# Altium schematic sheet msp430.SchDoc(msp430)
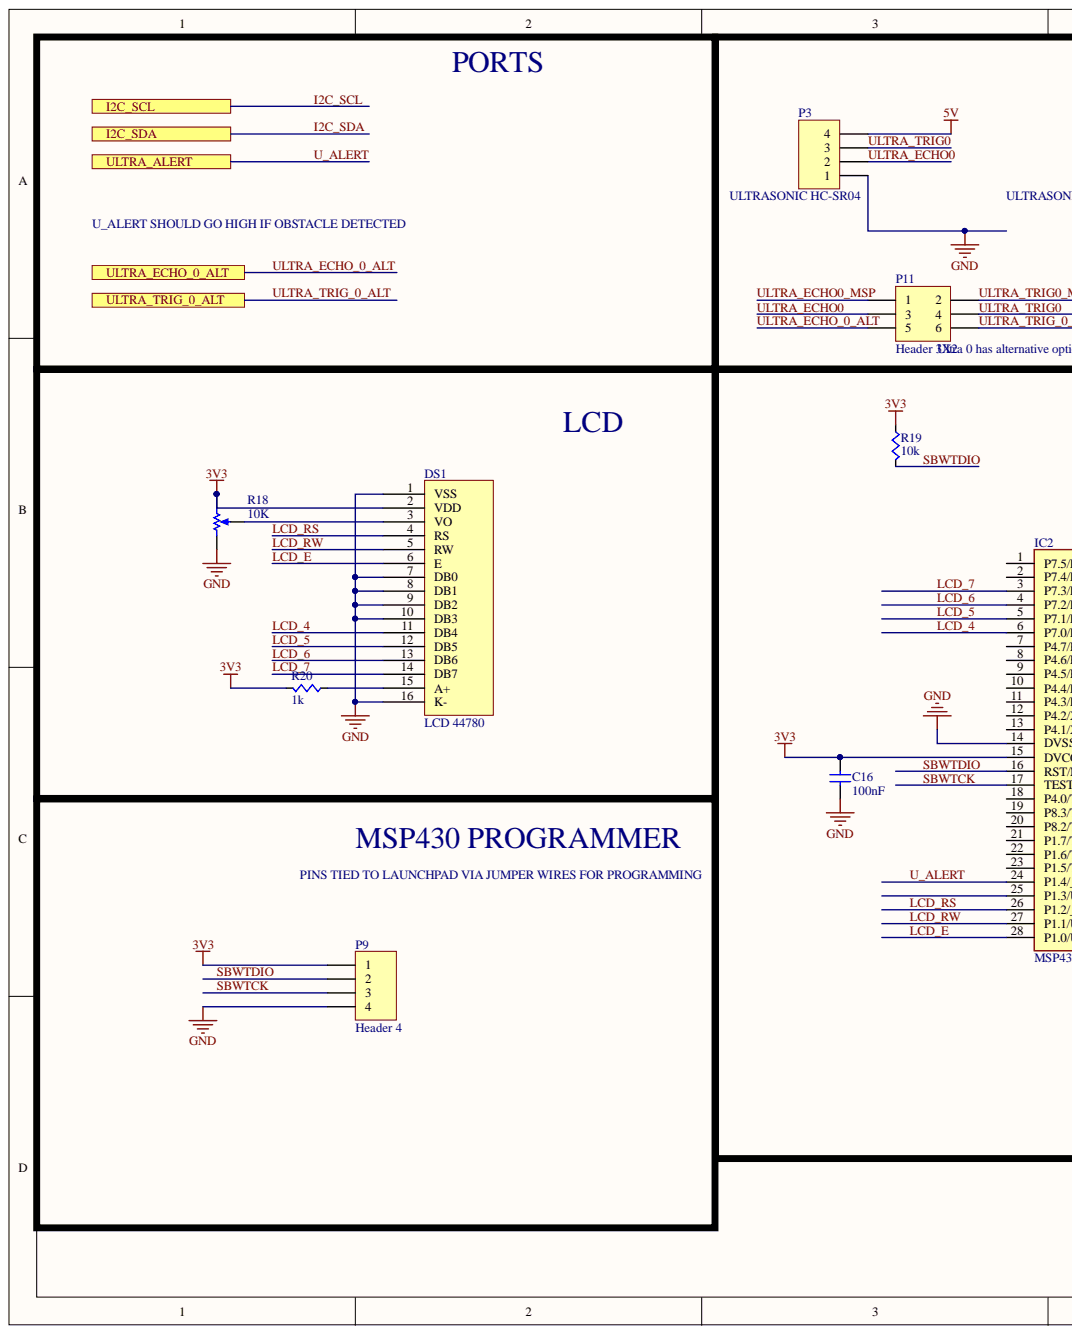
[diagram p0: msp430.SchDoc, left]
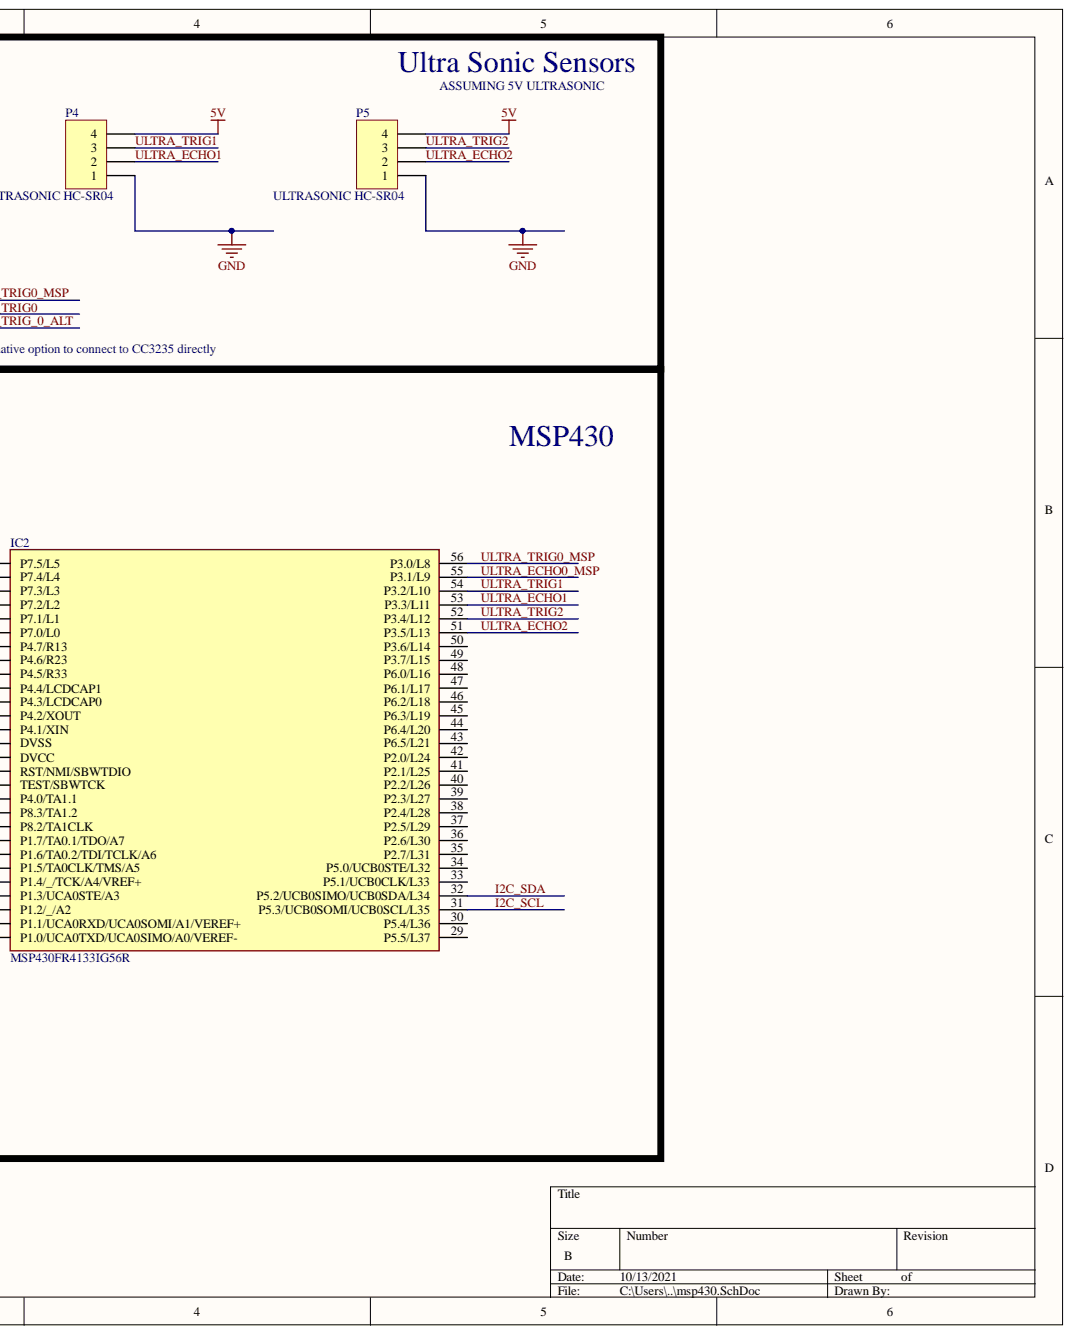
[diagram p1: msp430.SchDoc, right]

## Parts
C16  value 100nF  [CAPC0603]  (hidden comment: Cap)
DS1  LCD 44780  [OLED 16x2]
IC2  MSP430FR4133IG56R  [SOP50P810X120-56N]
P3  ULTRASONIC HC-SR04  [HDR1X4]
P4  ULTRASONIC HC-SR04  [HDR1X4]
P5  ULTRASONIC HC-SR04  [HDR1X4]
P9  Header 4  [HDR1X4]
P11  Header 3X2  [HDR2X3]
R18  value 10K  [POT inline wide]  (hidden comment: RPot_1)
R19  value 10k  [RES0603]  (hidden comment: Res)
R20  value 1k  [RES0603]  (hidden comment: Res)

## Ports
I2C_SCL, I2C_SDA, ULTRA_ALERT, ULTRA_ECHO_0_ALT, ULTRA_TRIG_0_ALT

## Nets
3V3: C16.1 DS1.2(VDD) IC2.15(DVCC) P9.1 R18.3 R19.2 R20.1
5V: P3.4 P4.4 P5.4
GND: C16.2 DS1.1(VSS) DS1.7(DB0) DS1.8(DB1) DS1.9(DB2) DS1.10(DB3) DS1.16(K-) IC2.14(DVSS) P3.1 P4.1 P5.1 P9.4 R18.1
I2C_SCL: IC2.31(P5.3/UCB0SOMI/UCB0SCL/L35) ; port I2C_SCL
I2C_SDA: IC2.32(P5.2/UCB0SIMO/UCB0SDA/L34) ; port I2C_SDA
LCD_4: DS1.11(DB4) IC2.6(P7.0/L0)
LCD_5: DS1.12(DB5) IC2.5(P7.1/L1)
LCD_6: DS1.13(DB6) IC2.4(P7.2/L2)
LCD_7: DS1.14(DB7) IC2.3(P7.3/L3)
LCD_E: DS1.6(E) IC2.28(P1.0/UCA0TXD/UCA0SIMO/A0/VEREF-)
LCD_RS: DS1.4(RS) IC2.26(P1.2/_/A2)
LCD_RW: DS1.5(RW) IC2.27(P1.1/UCA0RXD/UCA0SOMI/A1/VEREF+)
SBWTCK: IC2.17(TEST/SBWTCK) P9.3
SBWTDIO: IC2.16(RST/NMI/SBWTDIO) P9.2 R19.1
U_ALERT: IC2.24(P1.4/_/TCK/A4/VREF+) ; port ULTRA_ALERT
ULTRA_ECHO0: P3.2 P11.3
ULTRA_ECHO0_MSP: IC2.55(P3.1/L9) P11.1
ULTRA_ECHO1: IC2.53(P3.3/L11) P4.2
ULTRA_ECHO2: IC2.51(P3.5/L13) P5.2
ULTRA_ECHO_0_ALT: P11.5 ; port ULTRA_ECHO_0_ALT
ULTRA_TRIG0: P3.3 P11.4
ULTRA_TRIG0_MSP: IC2.56(P3.0/L8) P11.2
ULTRA_TRIG1: IC2.54(P3.2/L10) P4.3
ULTRA_TRIG2: IC2.52(P3.4/L12) P5.3
ULTRA_TRIG_0_ALT: P11.6 ; port ULTRA_TRIG_0_ALT
NetDS1_3: DS1.3(VO) R18.2
NetDS1_15: DS1.15(A+) R20.2

Not connected: IC2 1(P7.5/L5) 2(P7.4/L4) 7(P4.7/R13) 8(P4.6/R23) 9(P4.5/R33) 10(P4.4/LCDCAP1) 11(P4.3/LCDCAP0) 12(P4.2/XOUT) 13(P4.1/XIN) 18(P4.0/TA1.1) 19(P8.3/TA1.2) 20(P8.2/TA1CLK) 21(P1.7/TA0.1/TDO/A7) 22(P1.6/TA0.2/TDI/TCLK/A6) 23(P1.5/TA0CLK/TMS/A5) 25(P1.3/UCA0STE/A3) 29(P5.5/L37) 30(P5.4/L36) 33(P5.1/UCB0CLK/L33) 34(P5.0/UCB0STE/L32) 35(P2.7/L31) 36(P2.6/L30) 37(P2.5/L29) 38(P2.4/L28) 39(P2.3/L27) 40(P2.2/L26) 41(P2.1/L25) 42(P2.0/L24) 43(P6.5/L21) 44(P6.4/L20) 45(P6.3/L19) 46(P6.2/L18) 47(P6.1/L17) 48(P6.0/L16) 49(P3.7/L15) 50(P3.6/L14)

## Library data of the parts
IC2 = MSP430FR4133IG56R: Integrated Circuit; Manufacturer_Name: Texas Instruments; Mouser Price/Stock: https://www.mouser.com/Search/Refine.aspx?Keyword=595-SP430FR4133IG56R; Mouser Part Number: 595-SP430FR4133IG56R; Manufacturer_Part_Number: MSP430FR4133IG56R; Library Name: SamacSys.SchLib; Height: 1.2mm; Datasheet Link: http://www.ti.com/general/docs/suppproductinfo.tsp?distId=10&gotoUrl=http%3A%2F%2Fwww.ti.com%2Flit%2Fgpn%2Fmsp430fr4131; Component Kind: Standard; Arrow Price/Stock: https://www.arrow.com/en/products/msp430fr4133ig56r/texas-instruments; Arrow Part Number: MSP430FR4133IG56R
P3-P5 P9 = Header 4: Header, 4-Pin; Signal Integrity: Connector; Library Name: Miscellaneous Connectors.IntLib; LatestRevisionNote: Re-released for DXP Platform.; LatestRevisionDate: 17-Jul-2002; Component Kind: Standard; Publisher: Altium Limited
P11 = Header 3X2: Header, 3-Pin, Dual row; Signal Integrity: Connector; Library Name: Miscellaneous Connectors.IntLib; LatestRevisionNote: Re-released for DXP Platform.; LatestRevisionDate: 17-Jul-2002; Component Kind: Standard; Publisher: Altium Limited
R18 = RPot_3: Potentiometer; Sim Note: Enter the Resistance value and the set point into parameter fields.; Set Position: 0.5; Simulation: POT; Published: 8-Jun-2000; PackageReference: VR5; PackageDescription: Variable Resistor, Thru-Hole; 3 Leads; Library Name: ECE LIB new.SCHLIB; LatestRevisionNote: Re-released for DXP Platform.; LatestRevisionDate: 17-Jul-2002; Component Kind: Standard; Publisher: Altium Limited
C16 = Cap: Supplier Part Number 1: 1276-1000-1-ND; Supplier 1: Digi-Key; Library Name: Passives.SchLib; Component Kind: Standard
DS1 = LCD 44780: Library Name: ECE LIB new.SCHLIB; Component Kind: Standard
R19 R20 = Res: Supplier Part Number 1: RMCF0603JT10K0CT-ND; Supplier 1: Digi-Key; Library Name: Passives.SchLib; Component Kind: Standard
